# Altium schematic sheet Top.SchDoc(Top)
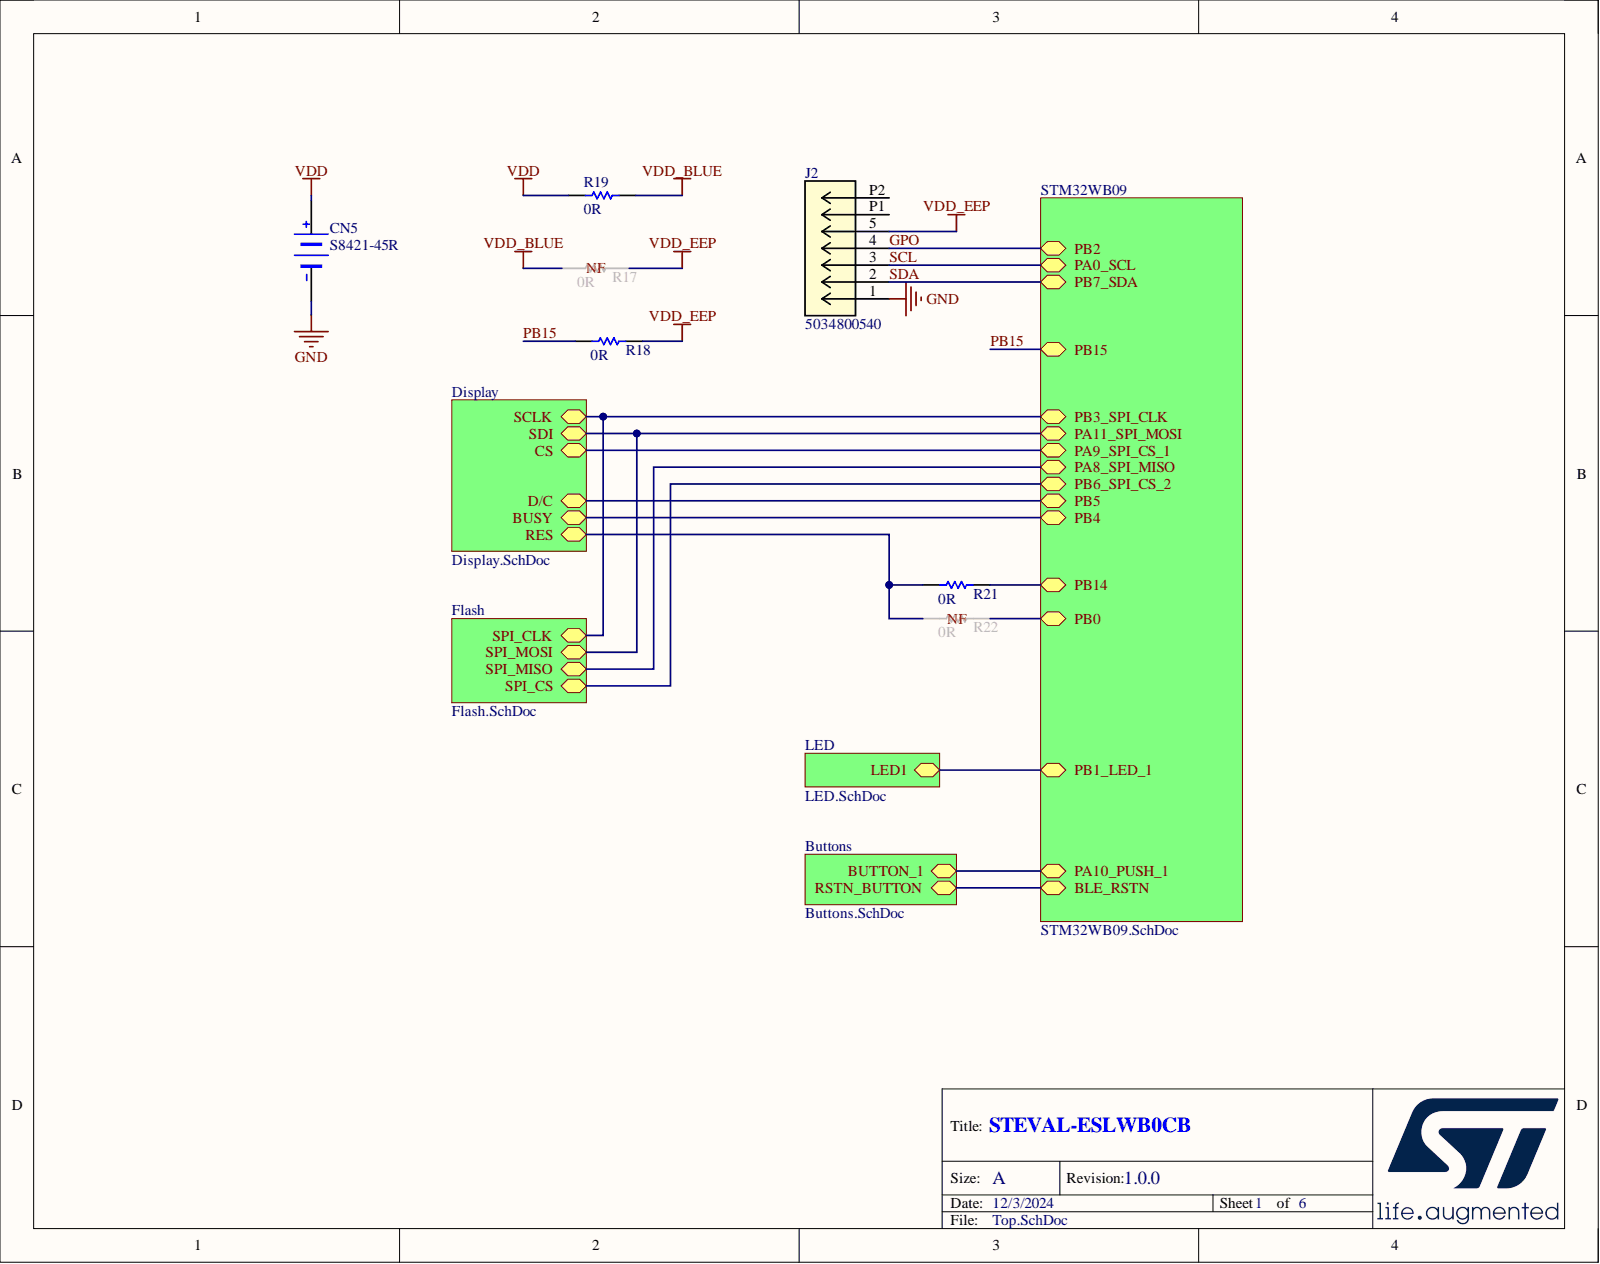
[diagram p0: Top.SchDoc]

## Parts
CN5  value S8421-45R  Manufacturer Part Number: S8421-45R  [BAT_HARWIN_S8421-45R_CR2032_SMD_2P]  (hidden comment: BATTERY HOLDER)
J2  5034800540  [CON_5034800540_MOL]
R17  value 0R  [R0402]  (hidden comment: Resistor)
R18  value 0R  [R0402]  (hidden comment: Resistor)
R19  value 0R  [R0603]  (hidden comment: Resistor)
R21  value 0R  [R0402]  (hidden comment: Resistor)
R22  value 0R  [R0402]  (hidden comment: Resistor)

## Nets
GND: CN5.2 J2.1
VDD: CN5.1 R19.1
VDD_BLUE: R17.1 R19.2
VDD_EEP: J2.5 R17.2 R18.2
GPO: J2.4
PB15: R18.1
SCL: J2.3
SDA: J2.2
NetJ2_P1: J2.P1
NetJ2_P2: J2.P2
NetR21_1: R21.1 R22.1
NetR21_2: R21.2
NetR22_2: R22.2
BLE_RSTN:
BUSY:
BUTTON_1:
CS:
D/C:
LED1:
PA8_SPI_MISO:
PA11_SPI_MOSI:
PB3_SPI_CLK:
PB6_SPI_CS_2:

## Library data of the parts
CN5 = MCD-02936: CR2032 compact battery holder; Manufacturer: HARWIN; Part_Preference: Recommended; Part_Type: Connector; Component_Country_Of_Origin: Taiwan; Database Table Name: MCD_PARTS$; ID: 5052; ShowFields: YES; Component Kind: Standard; ComponentLink1URL: http://tunmcdsvn.tun.st.com/Component_Documentation_Library/Trunk/Connector/HARWIN_S8421-45R; Internal_Part_Number: MCD-02936; Library Name: MCD_PARTS_database.DbLib; Manufacturer_Part_Number: S8421-45R; Order_Code_Digikey: 952-1737-2-ND; Order_Code_Farnell: 3517387; Order_Code_RS: N/A; Status: Checked
J2 = 5034800540_5_circuits: FFC/FPC Connector, 0.50mm Pitch, Dual Contact, 1.00mm Height, 5 Circuits, Gold over Nickel Plating; Manufacturer: Molex; Mfr_Name: Molex Connector Corporation; Category: FFC / FPC; Component Kind: Standard; Manufacturer Part Number: 5034800540; Manufacturer_Part_Number: 5034800540; Pricing 1: 1=0.844, 112=0.49, 337=0.484, 900=0.477, 2250=0.384 (EUR); Pricing 2: 1=0.85, 10=0.63, 25=0.5652, 50=0.5228, 100=0.4851, 250=0.442, 500=0.41382, 1000=0.38894 (USD); RefDes: RefDes; RoHS: No; Stock 1: 26696; Stock 2: 26076; Supplier 1: Farnell; Supplier 2: Digikey; Supplier Part Number 1: 3911206; Supplier Part Number 2: 900-5034800540CT-ND; Type: DEV; Copyright: Copyright (C) 2023 Ultra Librarian. All rights reserved.; Library Name: missing_parts.SchLib
R17 R18 R21 R22 = MCD-00052: Resistor, 0R, 0402, +/-5%, 1/16W; Manufacturer: YAGEO; Manufacturer Part Number: RC0402JR-070RL; Part_Preference: Recommended; Component_Country_Of_Origin: China; Database Table Name: MCD_PARTS$; ID: 2540; Order_Code_RS: 9232516RL; ShowFields: YES; Component Kind: Standard; ComponentLink1URL: http://tunmcdsvn.tun.st.com/Component_Documentation_Library/Trunk/Resistor/YAGEO_RC_L_Series; Internal_Part_Number: MCD-00052; Library Name: MCD_PARTS_database.DbLib; Manufacturer_Part_Number: RC0402JR-070RL; Order_Code_Digikey: 311-0.0JRCT-ND; Order_Code_Farnell: 9232516RL; Package: 0402; Part_Type: Resistor; Status: Released
R19 = MCD-00181: Resistor, 0R, 0603, +/- 0.5mR, 1/4W; Manufacturer: ROHM SEMICONDUCTOR; Manufacturer Part Number: PMR03EZPJ000; Part_Preference: Recommended; Component_Country_Of_Origin: Philippines, Thailand; Database Table Name: MCD_PARTS$; ID: 2691; ShowFields: YES; Component Kind: Standard; ComponentLink1URL: http://tunmcdsvn.tun.st.com/Component_Documentation_Library/Trunk/Resistor/ROHM_PMR_Series; Internal_Part_Number: MCD-00181; Library Name: MCD_PARTS_database.DbLib; Manufacturer_Part_Number: PMR03EZPJ000; Order_Code_Digikey: PMR03EZPJ000-ND; Order_Code_Farnell: N/A; Order_Code_RS: N/A; Package: 0603; Part_Type: Resistor; Status: Released
